# Altium schematic sheet [03] - CIRCUITO.SchDoc
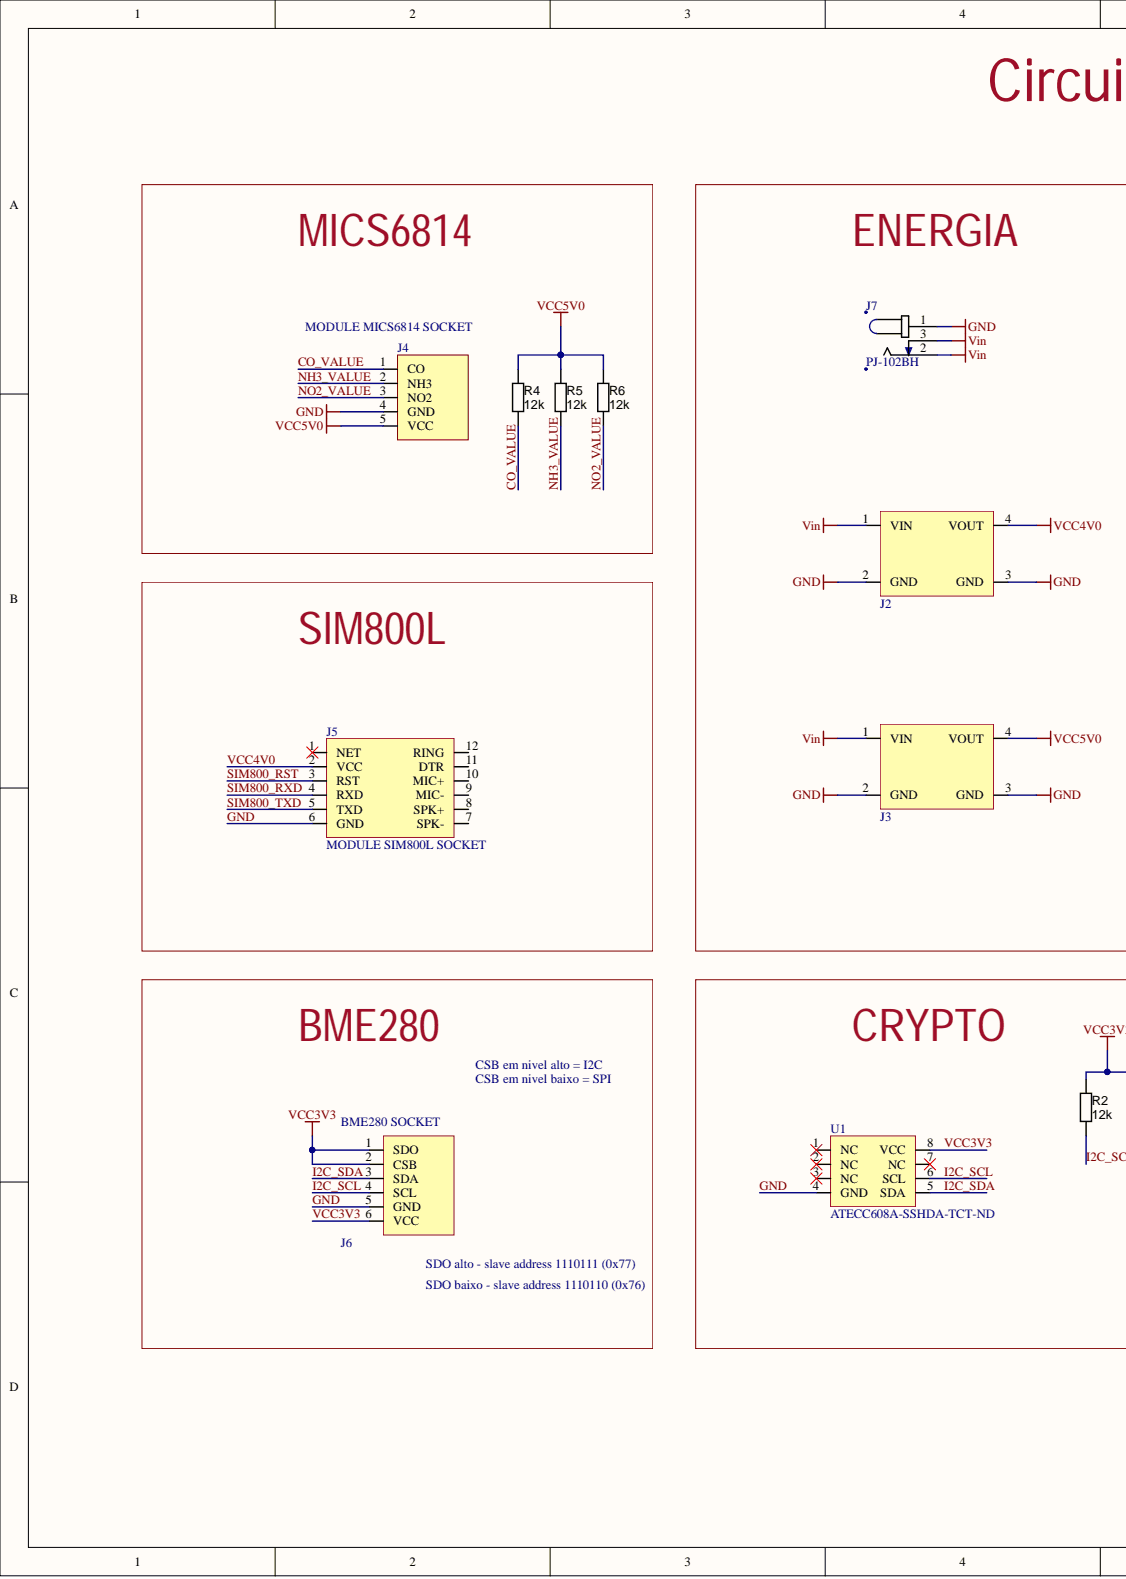
[diagram p0: [03] - CIRCUITO.SchDoc, left]
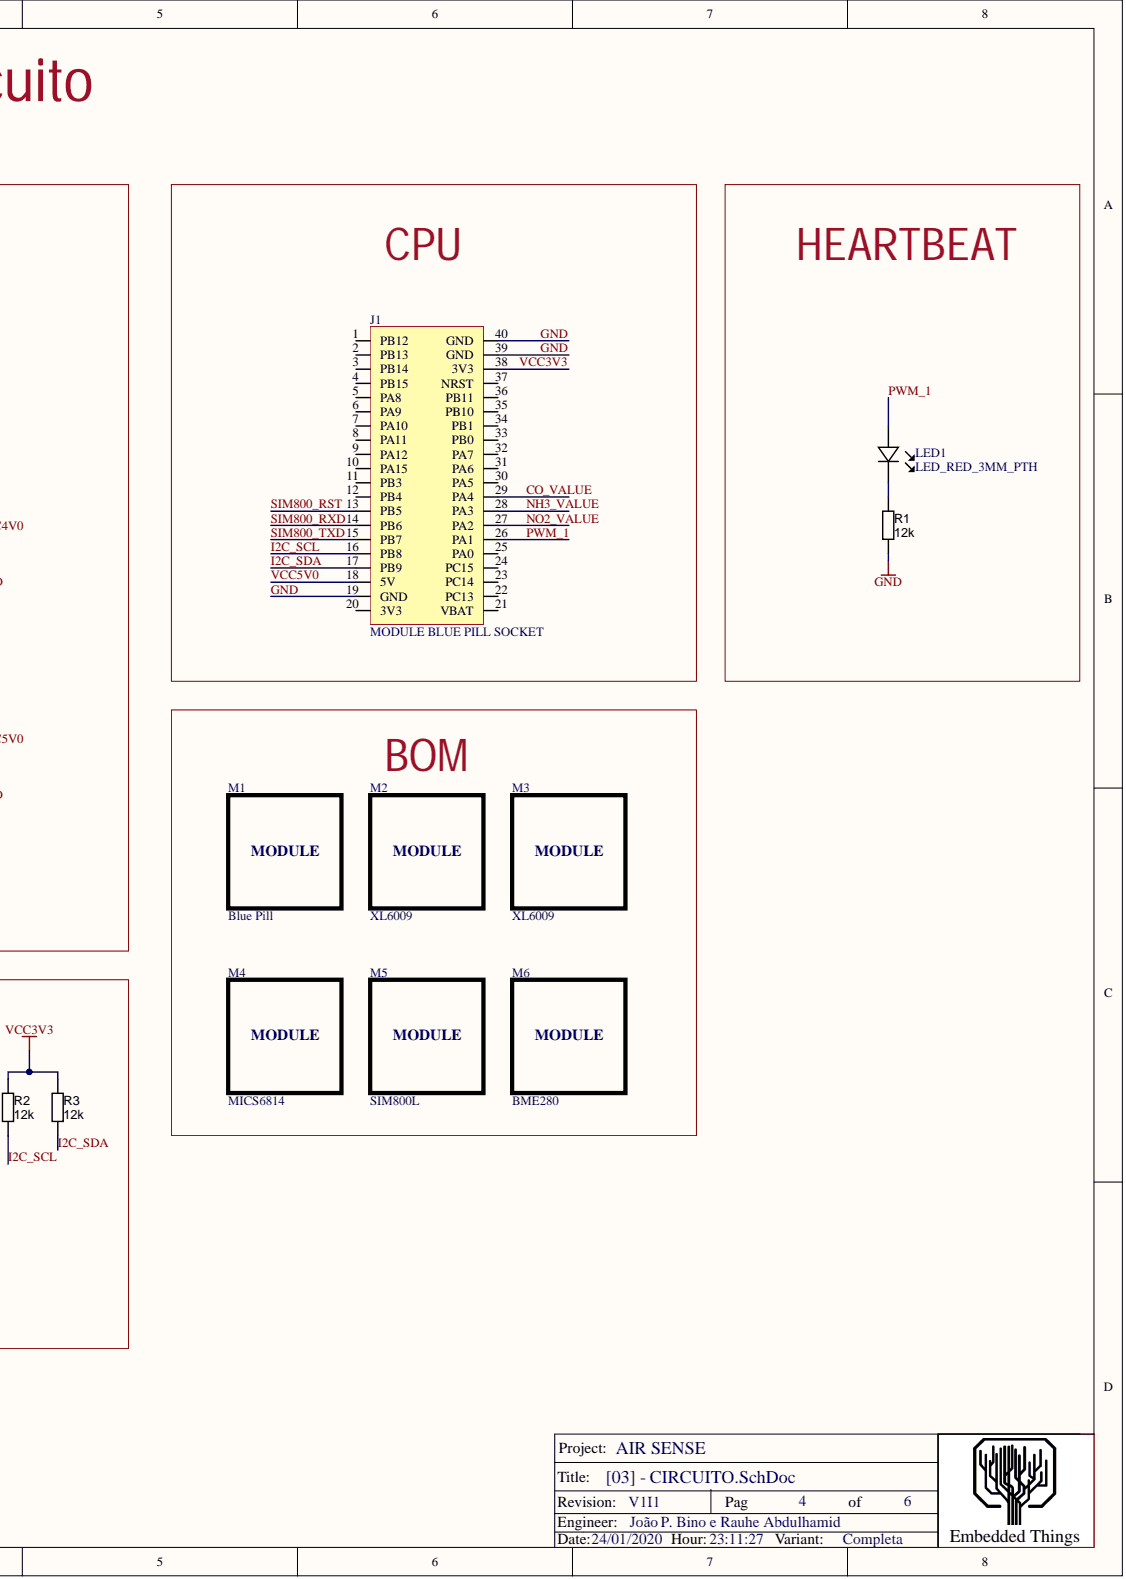
[diagram p1: [03] - CIRCUITO.SchDoc, right]

## Parts
J1  MODULE BLUE PILL SOCKET  [MODULE BLUE PILL SOCKET]
J2  XL6009  [MODULE DC-DC XL6009 SOCKET]  (value not drawn)
J3  XL6009  [MODULE DC-DC XL6009 SOCKET]  (value not drawn)
J4  MODULE MICS6814 SOCKET  [MODULE MICS6814 SOCKET]
J5  MODULE SIM800L SOCKET  [MODULE SIM800L SOCKET]
J6  Socket  [MODULE BME280 SOCKET]  (value not drawn)
J7  PJ-102BH  [CUI_PJ-102BH]
LED1  LED_RED_3MM_PTH  [LED-3MM-RED]
M1  Blue Pill
M2  XL6009
M3  XL6009
M4  MICS6814
M5  SIM800L
M6  BME280
R1  value 12k  [RESTH_AXIAL-0.4]  (hidden comment: Carbon Film)
R2  value 12k  [RESTH_AXIAL-0.4]  (hidden comment: Carbon Film)
R3  value 12k  [RESTH_AXIAL-0.4]  (hidden comment: Carbon Film)
R4  value 12k  [RESTH_AXIAL-0.4]  (hidden comment: Carbon Film)
R5  value 12k  [RESTH_AXIAL-0.4]  (hidden comment: Carbon Film)
R6  value 12k  [RESTH_AXIAL-0.4]  (hidden comment: Carbon Film)
U1  Supplier Part Number 1: ATECC608A-SSHDA-TCT-ND  [SOIC127P600X175-8AN]  (hidden comment: Authentication Chip)

## Nets
VIN: J2.1(VIN) J3.1(VIN) J7.2 J7.3
CO_VALUE: J1.29(PA4) J4.1(CO) R4.1
GND: J1.19(GND) J1.39(GND) J1.40(GND) J2.2(GND) J2.3(GND) J3.2(GND) J3.3(GND) J4.4(GND) J5.6(GND) J6.5(GND) J7.1 R1.1 U1.4(GND)
I2C_SCL: J1.16(PB8) J6.4(SCL) R2.1 U1.6(SCL)
I2C_SDA: J1.17(PB9) J6.3(SDA) R3.1 U1.5(SDA)
NH3_VALUE: J1.28(PA3) J4.2(NH3) R5.1
NO2_VALUE: J1.27(PA2) J4.3(NO2) R6.1
PWM_1: J1.26(PA1) LED1.A
SIM800_RST: J1.13(PB5) J5.3(RST)
SIM800_RXD: J1.14(PB6) J5.4(RXD)
SIM800_TXD: J1.15(PB7) J5.5(TXD)
VCC3V3: J1.38(3V3) J6.1(SDO) J6.2(CSB) J6.6(VCC) R2.2 R3.2 U1.8(VCC)
VCC4V0: J2.4(VOUT) J5.2(VCC)
VCC5V0: J1.18(5V) J3.4(VOUT) J4.5(VCC) R4.2 R5.2 R6.2
NetLED1_K: LED1.K R1.2

Not connected: J1 1(PB12) 2(PB13) 3(PB14) 4(PB15) 5(PA8) 6(PA9) 7(PA10) 8(PA11) 9(PA12) 10(PA15) 11(PB3) 12(PB4) 20(3V3) 21(VBAT) 22(PC13) 23(PC14) 24(PC15) 25(PA0) 30(PA5) 31(PA6) 32(PA7) 33(PB0) 34(PB1) 35(PB10) 36(PB11) 37(NRST); J5 1(NET) 7(SPK-) 8(SPK+) 9(MIC-) 10(MIC+) 11(DTR) 12(RING); U1 1(NC) 2(NC) 3(NC) 7(NC)

## Library data of the parts
J2 J3 = MODULE DC-DC XL6009 SOCKET: Component Kind: Standard; Library Name: airsense_lib.SchLib
J6 = MODULE BME280 SOCKET: CONN HEADER FEMALE 6POS .1" GOLD; Manufacturer: Sullins Connector Solutions; Manufacturer Part Number: PPPC061LFBN-RC; Number of Positions: 6; Number of Positions Loaded: All; Pitch: 0.100" (2.54mm); Category: Connectors, Interconnects; Color: Black; Component Kind: Standard; ComponentLink1Description: http://www.sullinscorp.com/drawings/78_P(N)PxCxxxLFBN-RC,_10492-H.pdf; ComponentLink1URL: http://www.sullinscorp.com/drawings/78_P(N)PxCxxxLFBN-RC,_10492-H.pdf; Connector Type: Header; Contact Finish: Gold; Contact Finish Thickness: Flash; Contact Type: Female Socket; Fastening Type: -; Features: -; Mounting Type: Through Hole; Number of Rows: 1; Packaging: Bulk; Pricing 1: 1=0.7, 10=0.546, 25=0.5088, 50=0.4626, 100=0.4163, 250=0.35152, 500=0.333, 1000=0.296, 2500=0.24605 (USD); RoHS: RoHS Compliant; Row Spacing: -; Stock 1: 15924; Supplier 1: Digi-Key; Supplier Part Number 1: S7039-ND; Termination: Solder; Library Name: airsense_lib.SchLib
J7 = PJ-102BH: CONN PWR JACK 2.5X5.5MM SOLDER; Manufacturer: CUI Inc.; Actual Diameter: 0.098" (2.50mm ID), 0.256" (6.50mm OD); Body Color: Black; Gender: Male; Industry Recognized Mating Diameter: 2.50mm ID (0.098"), 5.50mm OD (0.217"); Internal Switch(s): Single Switch, Normally Closed; Material Flammability Rating: UL94 V-0; Mating Length/Depth: 0.354" (9.00mm); Number of Positions/Contacts: 2 Conductors, 3 Contacts; Shielding: Unshielded; Voltage - Rated: 24VDC; Body Material: -; Category: Connectors, Interconnects; Component Kind: Standard; ComponentLink1Description: https://www.cui.com/product/resource/digikeypdf/pj-102bh.pdf; ComponentLink1URL: https://www.cui.com/product/resource/digikeypdf/pj-102bh.pdf; Connector Type: Jack; Contact Material: Brass; Copper Alloy; Contact Material - Plating: Silver; Features: -; Housing Material: Polybutylene Terephthalate (PBT); Includes: -; Ingress Protection: -; Insulation Color: -; Manufacturer Part Number: PJ-102BH; Mounting Feature: -; Mounting Type: Through Hole, Right Angle; Operating Temperature: -25°C ~ 85°C; Packaging: Tray; Part Status: Active; Pricing 1: 1=0.77, 10=0.72, 25=0.648, 50=0.576, 100=0.552, 280=0.504, 560=0.48, 1120=0.396, 2520=0.36 (USD); RoHS: RoHS Compliant; Stock 1: 7742; Supplier 1: Digi-Key; Supplier Part Number 1: CP-102BH-ND; Termination: Solder; Thread Size: -; Library Name: airsense_lib.SchLib
LED1 = LED_RED_3MM_PTH: LED RED 650NM 3MM RND; Manufacturer: Marktech Optoelectronics; Manufacturer Part Number: MT1403-RG-A; Current - Test: 20mA; Lens Style/Size: Round with Domed Top, 3.00mm; Lens Transparency: Clear; Millicandela Rating: 5mcd; Viewing Angle: 35°; Voltage - Forward (Vf) (Typ): 2.1V; Wavelength - Peak: 700nm; Category: Optoelectronics; Color: Red; Component Kind: Standard; ComponentLink1Description: http://www.marktechopto.com/products/datasheet/MT1403-RG-A; ComponentLink1URL: http://www.marktechopto.com/products/datasheet/MT1403-RG-A; Height (Max): 5.10mm; Lens Color: Red; Mounting Type: Through Hole; Package / Case: Radial; Packaging: Bulk; Pricing 1: 1=0.3, 10=0.219, 25=0.1612, 100=0.115, 250=0.0782, 500=0.069, 1000=0.05405, 2500=0.0529, 5000=0.046 (USD); RoHS: RoHS Compliant; Size / Dimension: -; Stock 1: 5274; Supplier 1: Digi-Key; Supplier Device Package: 3.0mm Round; Supplier Part Number 1: 1125-1182-ND; Wavelength - Dominant: 650nm; Library Name: jpnbino.SchLib
M1 = MODULE BLUE PILL: Component Kind: Standard; Library Name: airsense_lib.SchLib
M2 M3 = MODULE DC-DC XL6009: Component Kind: Standard; Library Name: airsense_lib.SchLib
M4 = MODULE MICS6814: Component Kind: Standard; Library Name: airsense_lib.SchLib
M5 = MODULE SIM800L: Component Kind: Standard; Library Name: airsense_lib.SchLib
M6 = MODULE BME280: Component Kind: Standard; Library Name: airsense_lib.SchLib
R1-R6 = RES_12K_Axial_0.25W_5%: Resistor THD Axial 12k Ohm 0.25W 5% Carbon Film; Manufacturer 1: Yageo; Manufacturer Part Number 1: CFR-25JB-12K; Ratings: 1/4W; SCDType: spec; Component Kind: Standard; Library Name: PBL - Resistors.SCHLIB; Package / Case: Axial; Supplier 1: Digi-Key; Supplier Part Number 1: 12KQBK-ND; Tolerance: ±5%
U1 = ATECC608: Authentication Chip IC Networking and Communications 8-SOIC; Manufacturer: Microchip Technology; Manufacturer Part Number: ATECC608A-SSHDA-T; Applications: Networking and Communications; Category: Integrated Circuits (ICs); Component Kind: Standard; ComponentLink1Description: http://www.microchip.com/mymicrochip/filehandler.aspx?ddocname=en601565; ComponentLink1URL: http://www.microchip.com/mymicrochip/filehandler.aspx?ddocname=en601565; Mounting Type: Surface Mount; Package / Case: 8-SOIC (0.154", 3.90mm Width); Packaging: Cut Tape (CT); Part Status: Active; Pricing 1: 1=0.91, 25=0.8644 (USD); RoHS: RoHS Compliant; Stock 1: 172; Supplier 1: Digi-Key; Supplier Device Package: 8-SOIC; Type: Authentication Chip; Library Name: airsense_lib.SchLib
J1 = MODULE BLUE PILL SOCKET: Component Kind: Standard; Library Name: airsense_lib.SchLib
J4 = MODULE MICS6814 SOCKET: Component Kind: Standard; Library Name: airsense_lib.SchLib
J5 = MODULE SIM800L SOCKET: Component Kind: Standard; Library Name: airsense_lib.SchLib
